# Altium schematic sheet Nixie_PSU.SchDoc(Nixie_PSU)
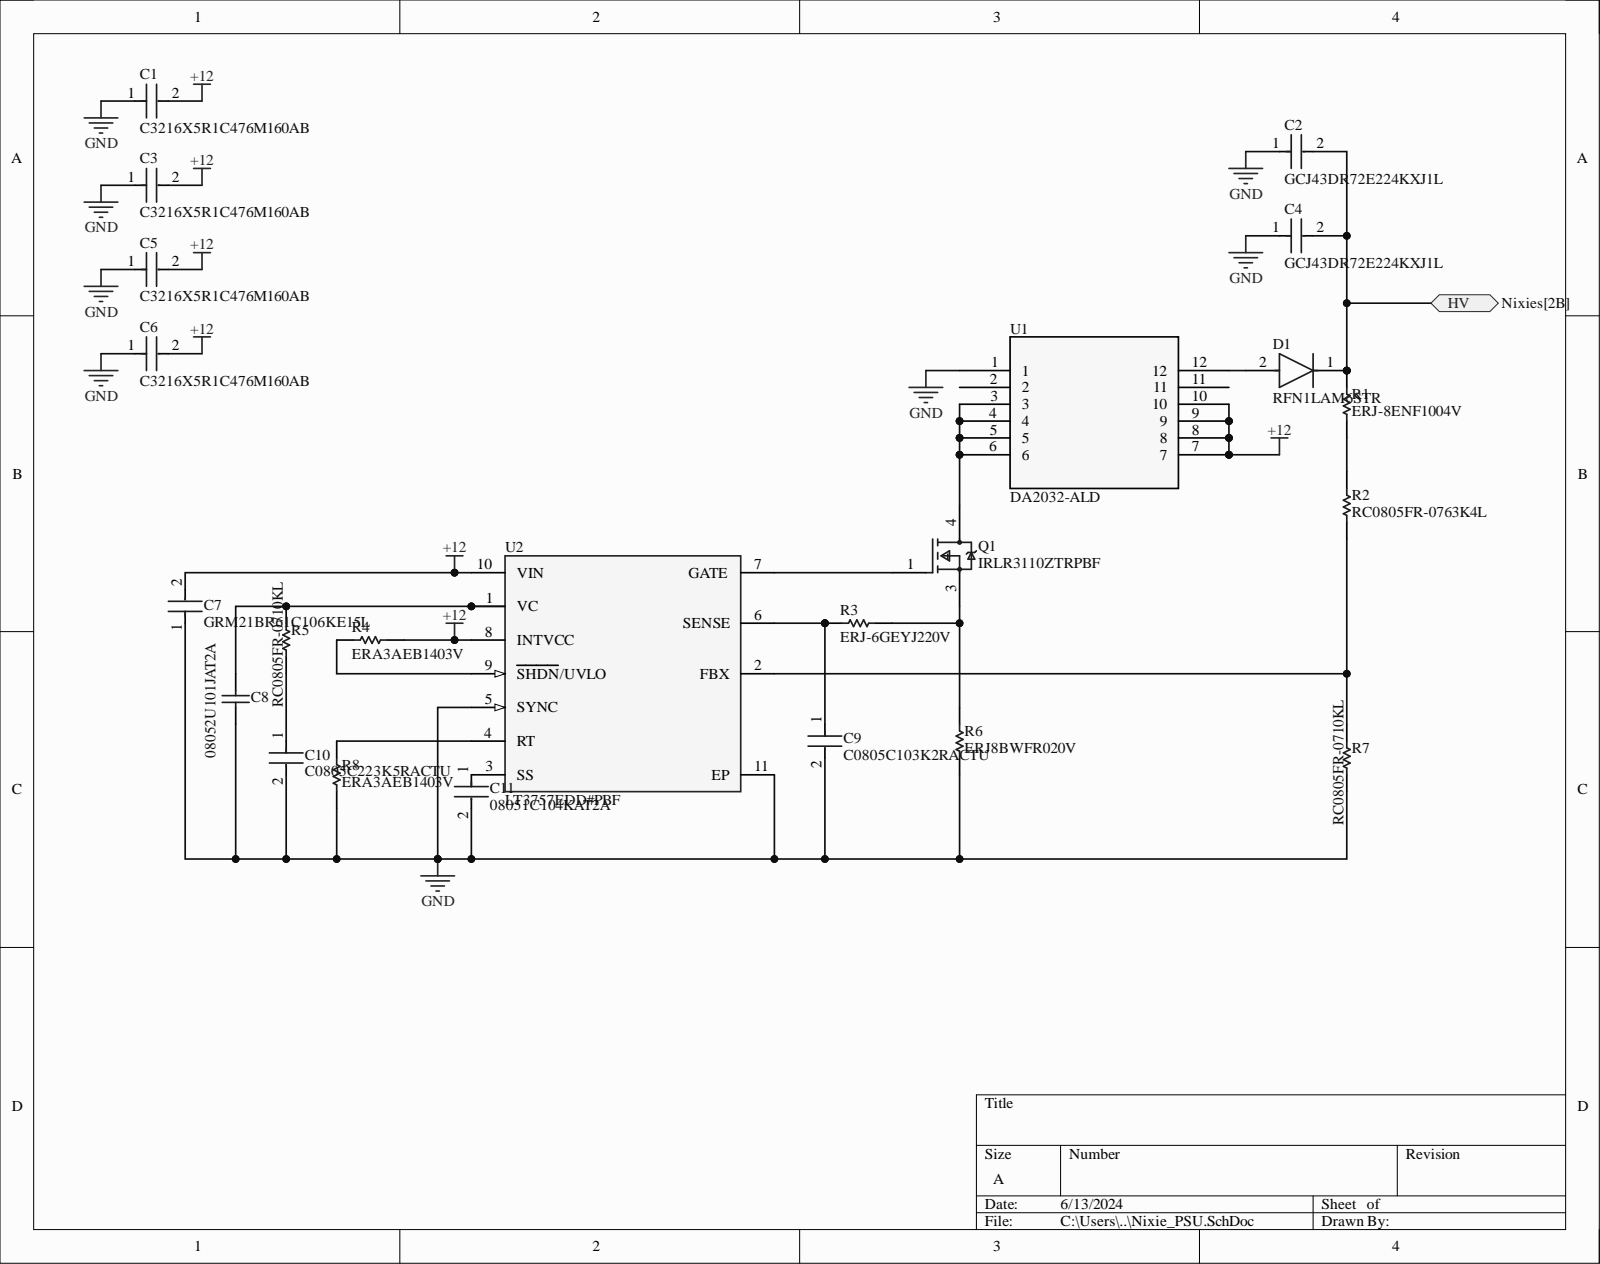
[diagram p0: Nixie_PSU.SchDoc]

## Parts
C1  C3216X5R1C476M160AB  [FP-C3216-160-0_2-MFG]
C2  GCJ43DR72E224KXJ1L  [FP-GCJ43D-0_4-IPC_C]
C3  C3216X5R1C476M160AB  [FP-C3216-160-0_2-MFG]
C4  GCJ43DR72E224KXJ1L  [FP-GCJ43D-0_4-IPC_C]
C5  C3216X5R1C476M160AB  [FP-C3216-160-0_2-MFG]
C6  C3216X5R1C476M160AB  [FP-C3216-160-0_2-MFG]
C7  GRM21BR61C106KE15L  [FP-GRM21B-0_1-IPC_C]
C8  08052U101JAT2A  [CAPC2013X115X51ML20T26]
C9  C0805C103K2RACTU  [FP-C0805C-DN-MFG]
C10  C0805C223K5RACTU  [FP-C0805C-DN-MFG]
C11  08051C104KAT2A  [FP-0805-L_2_01_0_2-W_1_25-IPC_B]
D1  RFN1LAM6STR  [FP-PMDTM-MFG]
Q1  IRLR3110ZTRPBF  [FP-PG-TO252-3-MFG]
R1  ERJ-8ENF1004V  [RESC3216X70X50NL05T20]
R2  RC0805FR-0763K4L  [RESC2013X60X35NL10T20]
R3  ERJ-6GEYJ220V  [RESC2013X70X40ML20T20]
R4  ERA3AEB1403V  [RESC1608X55X30LL20T20]
R5  RC0805FR-0710KL  [RESC2013X60X35NL10T20]
R6  ERJ8BWFR020V  [RESC3216X70X50LL05T20]
R7  RC0805FR-0710KL  [RESC2013X60X35NL10T20]
R8  ERA3AEB1403V  [RESC1608X55X30LL20T20]
U1  DA2032-ALD  [SOIC12_LT3750_DA2032_14P98X17P2_COC]
U2  LT3757EDD#PBF  [FP-DD-10-05-08-1699-IPC_A]

## Ports
HV

## Nets
+12: C1.2 C3.2 C5.2 C6.2 C7.2 R4.1 U1.7 U1.8 U1.9 U1.10 U2.8(INTVCC) U2.10(VIN)
GND: C1.1 C2.1 C3.1 C4.1 C5.1 C6.1 C7.1 C8.1 C9.2 C10.2 C11.2 R6.1 R7.1 R8.2 U1.1 U2.5(SYNC) U2.11(EP)
HV: C2.2 C4.2 D1.1 R1.2 ; port HV
NetC8_2: C8.2 R5.2 U2.1(VC)
NetC9_1: C9.1 R3.1 U2.6(SENSE)
NetC10_1: C10.1 R5.1
NetC11_1: C11.1 U2.3(SS)
NetD1_2: D1.2 U1.12
NetQ1_1: Q1.1 U2.7(GATE)
NetQ1_3: Q1.3 R3.2 R6.2
NetQ1_4: Q1.4 U1.3 U1.4 U1.5 U1.6
NetR1_1: R1.1 R2.2
NetR2_1: R2.1 R7.2 U2.2(FBX)
NetR4_2: R4.2 U2.9(SHDN/UVLO)
NetR8_1: R8.1 U2.4(RT)

Not connected: U1 2 11

## Library data of the parts
C1 C3 C5 C6 = CMP-2000-07442-2: Multilayer Ceramic Capacitors 47uF ±20% 16V X5R SMD 1206; Capacitance: 47uF; Case Code (Imperial): 1206; Case Code (Metric): 3216; Case/Package: 1206; Component Kind: Standard; Construction: Flat; Depth: 1.6mm; Dielectric: X5R; Dielectric Material: Ceramic; Height: 1.6mm; Lead Free: Lead Free; Length: 3.2mm; Library Name: Altium Content Vault; Max Operating Temperature: 85°C; Min Operating Temperature: -55°C; Mount: Surface Mount; Package Quantity: 1; Packaging: Tape and Reel; Radiation Hardening: No; REACH SVHC: No SVHC; Resistor Type: Ceramic; RoHS Compliant: Yes; Series: C; Termination: SMD/SMT; Thickness: 0.071inch; Tolerance: 20%; Voltage Rating: 16V; Voltage Rating (DC): 16V; Weight: 0.000571oz; Width: 0.063inch
C2 C4 = CMP-06035-007963-1: 0.22uF ±10% 250V Ceramic Capacitor X7R 1812 (4532 Metric); Capacitance: 220nF; Case Code (Imperial): 1812; Case Code (Metric): 4532; Case/Package: 1812; Component Kind: Standard; Depth: 3.2mm; Dielectric: X7R; Features: Soft Termination; Height: 2mm; Length: 4.5mm; Library Name: Altium Content Vault; Max Operating Temperature: 125°C; Min Operating Temperature: -55°C; Mount: Surface Mount; Package Quantity: 1; Packaging: Tape and Reel; Radiation Hardening: No; Ratings: AEC-Q200; REACH SVHC: No SVHC; Termination: SMD/SMT; Thickness: 0.079inch; Tolerance: 10%; Voltage Rating: 250V; Voltage Rating (DC): 250V; Width: 0.126inch
C7 = CMP-2007-03453-2: Chip Multilayer Ceramic Capacitors for General Purpose, 0805, 10uF, X5R, 15%, 10%, 16V; Capacitance: 10uF; Case Code (Imperial): 0805; Case Code (Metric): 2012; Case/Package: 0805; Component Kind: Standard; Depth: 1.25mm; Dielectric: X5R; Height: 1.25mm; Lead Free: Lead Free; Length: 2mm; Library Name: Altium Content Vault; Material: Ceramic; Max Operating Temperature: 85°C; Min Operating Temperature: -55°C; Mount: Surface Mount; Package Quantity: 1; Packaging: Tape and Reel; Radiation Hardening: No; REACH SVHC: No SVHC; Termination: SMD/SMT; Thickness: 0.053inch; Tolerance: 10%; Voltage: 16V; Voltage Rating: 16V; Voltage Rating (DC): 16V; Width: 1.25mm
C8 = CMP-2007-04861-1: Manufacturer: AVX Interconnect / Elco; Component Kind: Standard; Library Name: Altium Content Vault; Part Number: 08052U101JAT2A
C9 = CMP-2007-00259-2: CAP CER 10000PF 200V X7R 0805; Manufacturer: KEMET; Capacitance: 10,000 pF; Material: Ceramic; Temperature Coefficient: 30ppm/°C; Tolerance: 0.1; Value: 10,000 pF; Automotive: No; Category: Cap; Component Kind: Standard; ComponentLink2Description: Datasheet; ComponentLink2URL: https://content.kemet.com/datasheets/KEM_C1003_C0G_SMD.pdf; Device Class L1: Passive Components; Device Class L2: Capacitors; Device Class L3: Ceramic Capacitors; Digikey Description: CAP CER 10000PF 200V X7R 0805; Height: 0.88mm; Ipc Land Pattern Name: CAPC200125X78; Lead Free: Yes; Library Name: Altium Content Vault; Manufacturer Part Number: C0805C103K2RACTU; Mouser Description: KEMET - C0805C103K2RACTU - CAP, MLCC, X7R, 10NF, 200V, 0805; Octopart: https://octopart.com/c0805c103k2ractu-kemet-9138347; Package: C0805C-DN; RoHS: TRUE; Temperature: -55°C to +125°C; Temperature Characteristic: X7R; Temperature Range High: +125°C; Temperature Range Low: -55°C; Voltage Rating: 200 V
C10 = CMP-2007-00338-2: CAP CER 0.022UF 50V X7R 0805; Manufacturer: KEMET; Capacitance: 22,000 pF; Material: Ceramic; Temperature Coefficient: 30ppm/°C; Tolerance: 0.1; Value: 22,000 pF; Automotive: No; Category: Cap; Component Kind: Standard; ComponentLink2Description: Datasheet; ComponentLink2URL: https://content.kemet.com/datasheets/KEM_C1003_C0G_SMD.pdf; Device Class L1: Passive Components; Device Class L2: Capacitors; Device Class L3: Ceramic Capacitors; Digikey Description: CAP CER 0.022UF 50V X7R 0805; Height: 0.88mm; Ipc Land Pattern Name: CAPC200125X78; Lead Free: Yes; Library Name: Altium Content Vault; Manufacturer Part Number: C0805C223K5RACTU; Mouser Description: Capacitor; Ceramic; Cap; .022uF; Tol 10%; SMT; Vol-Rtg 50V; X7R; Tape and Reel; Octopart: https://octopart.com/c0805c223k5ractu-kemet-145968; Package: C0805C-DN; RoHS: TRUE; Temperature: -55°C to +125°C; Temperature Characteristic: X7R; Temperature Range High: +125°C; Temperature Range Low: -55°C; Voltage Rating: 50 V
C11 = CMP-2007-04174-2: General Purpose Ceramic Capacitor, 0805, 100nF, 10%, X7R, 15%, 100V; Capacitance: 100nF; Case Code (Imperial): 0805; Case Code (Metric): 2012; Case/Package: 0805; Component Kind: Standard; Construction: Flat; Contact Plating: Tin; Depth: 1.25mm; Dielectric: X7R; Dielectric Material: Ceramic; Height: 1.4mm; Lead Free: Lead Free; Length: 2.01mm; Library Name: Altium Content Vault; Max Operating Temperature: 125°C; Min Operating Temperature: -55°C; Mount: Surface Mount; Number of Pins: 2; Package Quantity: 2000; Packaging: Tape and Reel; Radiation Hardening: No; REACH SVHC: No SVHC; RoHS Compliant: Yes; Series: -; Termination: SMD/SMT; Thickness: 0.055inch; Tolerance: 10%; Voltage: 100V; Voltage Rating: 100V; Voltage Rating (DC): 100V; Width: 0.049inch
D1 = CMP-08972-000003-1: DIODE GEN PURP 600V 800MA PMDTM; Manufacturer: Rohm; Forward Current: 0.8A; Max Junction Temp: +150°C; Max Surge Forward Current: 15A; Peak Reverse Current: 1uA; Automotive: No; Category: Diode; Component Kind: Standard; ComponentLink2Description: Datasheet; ComponentLink2URL: https://www.rohm.com/datasheet/RFN1LAM6S/rfn1lam6s-e; Device Class L1: Discrete Semiconductors; Device Class L2: Diodes; Device Class L3: Rectifier Diodes; Digikey Description: DIODE GEN PURP 600V 800MA PMDTM; Height: 1.05mm; Lead Free: Yes; Library Name: Altium Content Vault; Manufacturer Part Number: RFN1LAM6STR; Max Repetitive Reverse Voltage: 600V; Octopart: https://octopart.com/rfn1lam6str-rohm-81877486; Package: PMDTM; Reverse Voltage: 600V; RoHS: TRUE; Temperature: to +150°C; Temperature Range High: +150°C
Q1 = CMP-32901-000150-1: MOSFET N-CH 100V 42A DPAK; Manufacturer: Infineon; Depletion Mode: False; Drain To Source Resistance: 12mΩ; Gate Charge At Vgs: 34nC @ 4.5V; Gate To Source Voltage: 16V; Input Capacitace At Vds: 3980pF @ 25V; Max Forward Diode Voltage: 1.3V; Max Junction Temp: +175°C; Number Of Channels: 1; Power Dissipation: 140W; Pulse Drain Current: 250A; Reverse Recovery Charge: 42nC; Reverse Recovery Time: 34ns; Rthja Max: 40°C/W; Threshold Vgs Max: 2.5V; Threshold Vgs Min: 1V; Turn Off Delay Time: 33ns; Turn On Delay Time: 24ns; Automotive: No; Category: Trans; Component Kind: Standard; ComponentLink2Description: Datasheet; ComponentLink2URL: https://www.infineon.com/dgdl/irlr3110zpbf.pdf?fileId=5546d462533600a40153566cf6e2268a; Continuous Drain Current: 42A; Device Class L1: Discrete Semiconductors; Device Class L2: Transistors; Device Class L3: MOSFETs; Digikey Description: MOSFET N-CH 100V 42A DPAK; Drain To Source Breakdown Voltage: 100V; Drain To Source Voltage: 100V; Footprint Url: https://www.infineon.com/dgdl/po-dpak.pdf?fileId=5546d462580663ef0158068dbd7a01d5; Height: 2.52mm; Lead Free: Yes; Library Name: Altium Content Vault; Manufacturer Part Number: IRLR3110ZTRPBF; Number Of N Channels: 1; Octopart: https://octopart.com/irlr3110ztrpbf-infineon-65875028; Package: PG-TO252-3; RoHS: TRUE; Temperature: -55°C to +175°C; Temperature Range High: +175°C; Temperature Range Low: -55°C
R1 = CMP-2003-00020-1: Manufacturer: Panasonic; Component Kind: Standard; Library Name: Altium Content Vault; Part Number: ERJ-8ENF1004V
R2 = CMP-2001-01229-1: Manufacturer: Yageo; Component Kind: Standard; Library Name: Altium Content Vault; Part Number: RC0805FR-0763K4L
R3 = CMP-2001-00374-1: Manufacturer: Panasonic; Component Kind: Standard; Library Name: Altium Content Vault; Part Number: ERJ-6GEYJ220V
R4 R8 = CMP-2000-00911-1: Manufacturer: Panasonic; Component Kind: Standard; Library Name: Altium Content Vault; Part Number: ERA3AEB1403V
R5 R7 = CMP-1659-00013-4: Chip Resistor, 10 KOhm, +/- 1%, 0.125 W, -55 to 155 degC, 0805 (2012 Metric), RoHS, Tape and Reel; Manufacturer: Yageo; Voltage Rating: 150 V; Component Kind: Standard; ComponentLink1Description: Manufacturer URL; ComponentLink1URL: http://www.yageo.com/; ComponentLink2Description: Datasheet; ComponentLink2URL: http://www.yageo.com/documents/recent/PYu-RC_Group_51_RoHS_L_04.pdf; DatasheetVersion: Rev. 4, 02/2016; Height: 0.5 mm; Length: 2 mm; Library Name: Altium Content Vault; Max Operating Temperature: 155 degC; Min Operating Temperature: -55 degC; Mount: Surface Mount; Mounting Technology: Surface Mount; Package Quantity: 5000; PackageDescription: 2-Pin Surface Mounted Device, Body 2 x 1.25 mm; PackageReference: 0805; Packaging: Tape and Reel; Pins: 2; REACH SVHC: No SVHC; RoHSCompliant: true
R6 = CMP-2003-00770-1: Manufacturer: Panasonic; Component Kind: Standard; Library Name: Altium Content Vault; Part Number: ERJ8BWFR020V
U1 = CMP-080-000000-1: No Description Available; Mfr_Name: Coilcraft; Component Kind: Standard; Design Item ID: DA2032-ALD; RefDes: RefDes; Source: DA2032-ALD.SchLib; Type: Type; Library Name: Purdue University
U2 = CMP-09139-000444-1: IC REG CTRLR MULT TOPOLOGY 10DFN; Clock Sync: Yes; Max Input Voltage: 40V; Max Output Current: 12uA; Max Output Voltage: 350V; Min Input Voltage: 2.9V; Min Output Voltage: 1.569V; Number of Output Phases: 1; Output Current: 25A; Switching Frequency: 1MHz; Synchronous Rectifier: No; Topology: Boost; Case/Package: DFN; Component Kind: Standard; Height: 0.7mm; Length: 3mm; Library Name: Altium Content Vault; Max Operating Temperature: 125°C; Max Supply Voltage: 40V; Min Operating Temperature: -40°C; Min Supply Voltage: 2.9V; Mount: Surface Mount; Number of Outputs: 1; Number of Pins: 10; Output Voltage: 350V; Package Quantity: 121; Packaging: Rail/Tube; REACH SVHC: No SVHC; RoHS Compliant: Yes; Width: 3mm
